# Altium schematic sheet 02_SRAD_Avionics.SchDoc(02_SRAD_Avionics)
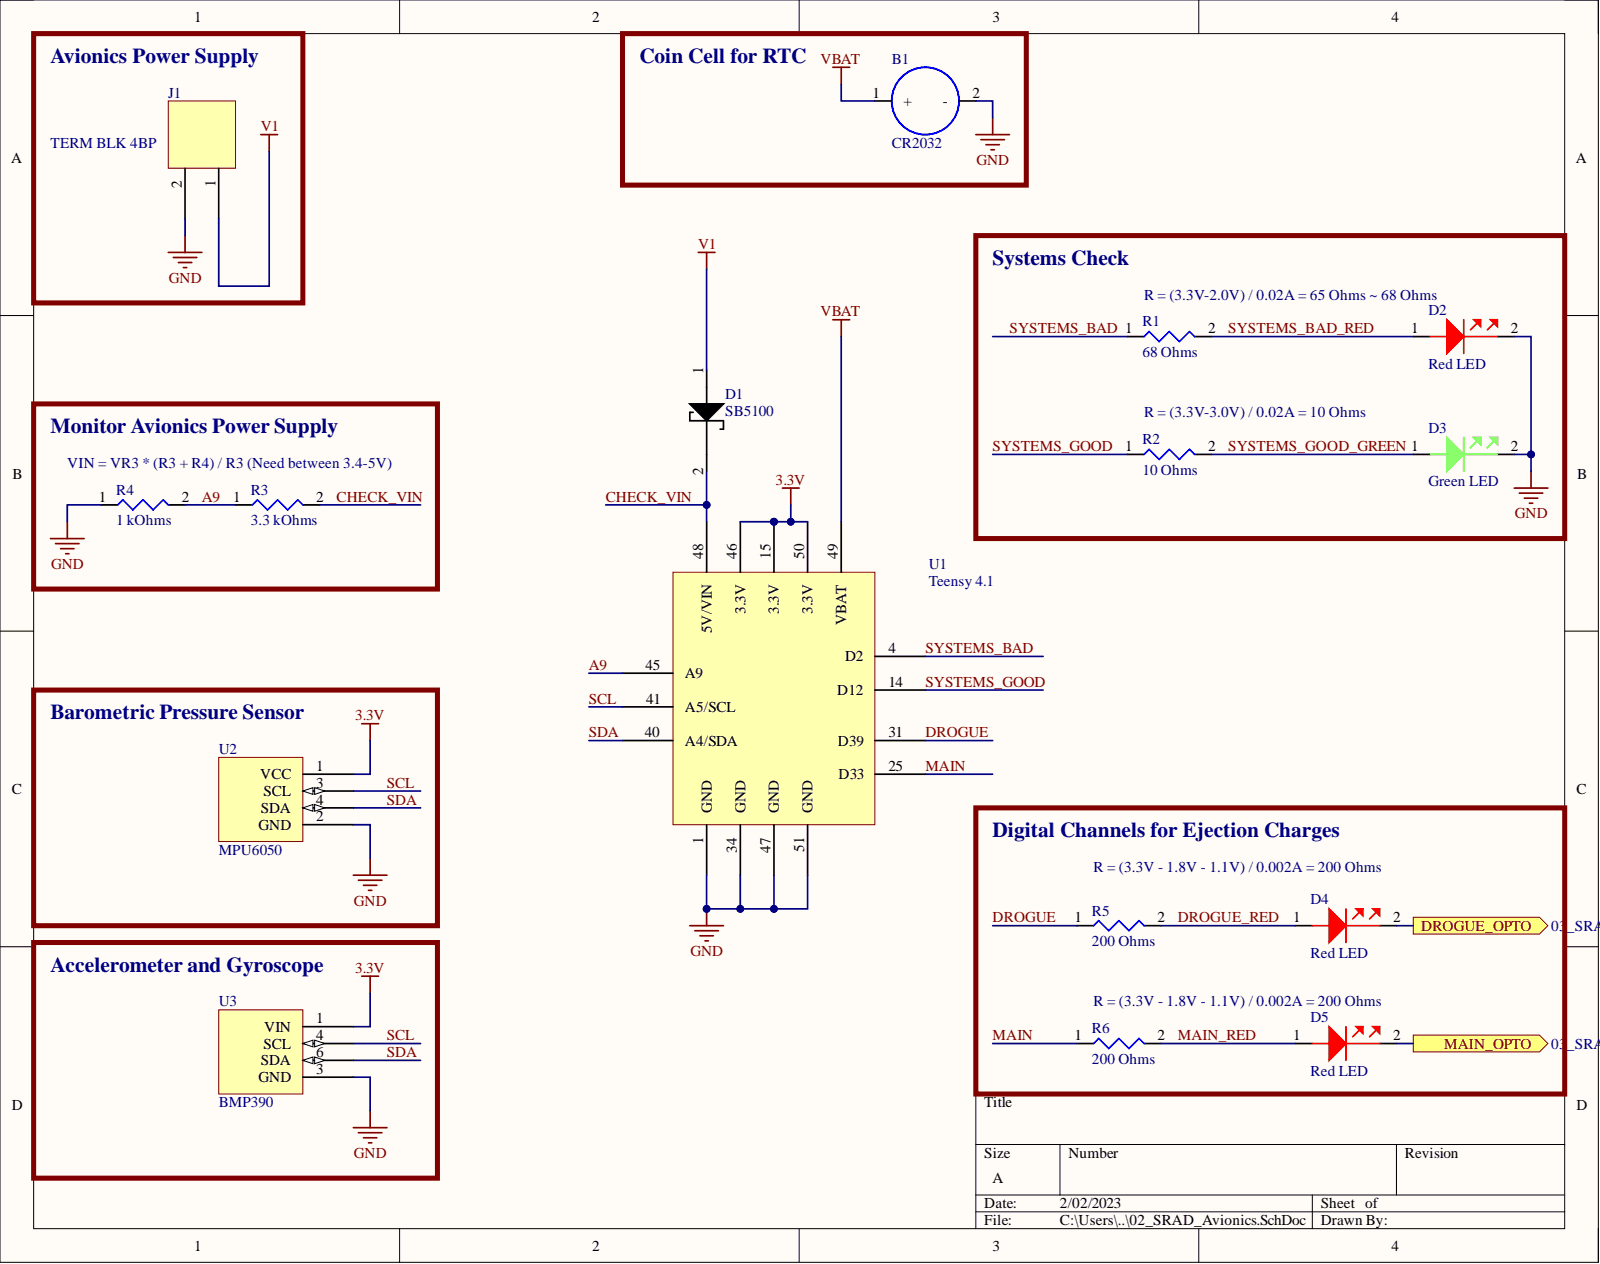
[diagram p0: 02_SRAD_Avionics.SchDoc]

## Parts
B1  CR2032  [CR2032]
D1  SB5100  [SB5100 Schottky Diode]
D2  Red LED  [Red LED THT]
D3  Green LED  Color: Green  [Green LED THT]
D4  Red LED  [Red LED THT]
D5  Red LED  [Red LED THT]
J1  TERM BLK 4BP  [PCB TERM BLK 2P 2.54MM]
R1  68 Ohms  [68 Ohm Yageo SMD]
R2  10 Ohms  [10 Ohm Yageo SMD]
R3  3.3 kOhms  [3.3 kOhm Yageo SMD]
R4  1 kOhms  [1 kOhm Yageo SMD]
R5  200 Ohms  [200 Ohm Yageo SMD]
R6  200 Ohms  [200 Ohm Yageo SMD]
U1  Teensy 4.1  [Teensy 4.1]
U2  MPU6050  [MPU6050]
U3  BMP390  [BMP390]

## Ports
DROGUE_OPTO, MAIN_OPTO

## Nets
3.3V: U1.15(3.3V) U1.46(3.3V) U1.50(3.3V) U2.1(VCC) U3.1(VIN)
GND: B1.2 D2.2 D3.2 J1.2 R4.1 U1.1(GND) U1.34(GND) U1.47(GND) U1.51(GND) U2.2(GND) U3.3(GND)
V1: D1.1 J1.1
VBAT: B1.1 U1.49(VBAT)
A9: R3.1 R4.2 U1.45(A9)
CHECK_VIN: D1.2 R3.2 U1.48(5V/VIN)
DROGUE: R5.1 U1.31(D39)
DROGUE_OPTO: D4.2 ; port DROGUE_OPTO
DROGUE_RED: D4.1 R5.2
MAIN: R6.1 U1.25(D33)
MAIN_OPTO: D5.2 ; port MAIN_OPTO
MAIN_RED: D5.1 R6.2
SCL: U1.41(A5/SCL) U2.3(SCL) U3.4(SCL)
SDA: U1.40(A4/SDA) U2.4(SDA) U3.6(SDA)
SYSTEMS_BAD: R1.1 U1.4(D2)
SYSTEMS_BAD_RED: D2.1 R1.2
SYSTEMS_GOOD: R2.1 U1.14(D12)
SYSTEMS_GOOD_GREEN: D3.1 R2.2

## Library data of the parts
B1 = CR2032: CR2032 Coin cell battery of 3V.; Component Kind: Standard; Library Name: SRAD_SAC_Schlib.SchLib
D1 = SB5100 Schottky Diode: Diode 100 V 5A Through Hole; Manufacturer: ON Semiconductor / Fairchild; Element Configuration: Single; Forward Voltage: 850mV; Max Forward Surge Current (Ifsm): 100A; Max Repetitive Reverse Voltage (Vrrm): 100V; Max Reverse Current: 500uA; Max Surge Current: 150A; Polarity: Standard; Average Rectified Current: 5A; Case/Package: DO-201AD; Category: Uncategorized; Component Kind: Standard; ComponentLink1Description: https://datasheet.ciiva.com/911/sb5100-911093.pdf?src-supplier=Avnet; ComponentLink1URL: https://datasheet.ciiva.com/911/sb5100-911093.pdf?src-supplier=Avnet; ComponentLink2Description: https://datasheet.ciiva.com/4714/sb5100-191746-4714390.pdf?src-supplier=Mouser; ComponentLink2URL: https://datasheet.ciiva.com/4714/sb5100-191746-4714390.pdf?src-supplier=Mouser; ComponentLink3Description: https://datasheet.ciiva.com/7965/78621-7965207.pdf?src-supplier=Element14; ComponentLink3URL: https://datasheet.ciiva.com/7965/78621-7965207.pdf?src-supplier=Element14; ComponentLink4Description: https://datasheet.ciiva.com/911/sb5100-911279.pdf?src-supplier=Digi-Key; ComponentLink4URL: https://datasheet.ciiva.com/911/sb5100-911279.pdf?src-supplier=Digi-Key; ComponentLink5Description: https://datasheet.ciiva.com/911/sb5100-911278.pdf?src-supplier=Digi-Key; ComponentLink5URL: https://datasheet.ciiva.com/911/sb5100-911278.pdf?src-supplier=Digi-Key; Current Rating: 5A; Diameter: 5.6mm; Forward Current: 5A; Height: 9.5mm; Lead Free: Lead Free; Length: 0.25inch; Manufacturer Part Number: SB5100; Max Operating Temperature: 150°C; Max Reverse Leakage Current: 500uA; Max Reverse Voltage (DC): 100V; Min Operating Temperature: -50°C; Mounting Technology: Through Hole; Output Current: 5A; Package Quantity: 1; Packaging: Tape and Reel; Peak Non-Repetitive Surge Current: 150A; Pins: 2; Pricing 1: 1=1.21000 (USD); Radiation Hardening: No; Reach SVHC Compliant: Yes; RoHS: Yes; RoHS Compliant: Yes; Series: -; Stock 1: 1; Supplier 1: Digi-Key; Supplier Part Number 1: SB5100FSCT-ND; Voltage Rating (DC): 100V; Weight: 0.36g; Width: 0.25inch; Library Name: SRAD_SAC_Schlib.SchLib
D2 D4 D5 = Red LED THT: Red 627nm LED Lighting Color HL3P Radial HL3P-BR60-J00DD; Manufacturer: Broadcom; Category: Uncategorized; Component Kind: Standard; Manufacturer Part Number: HL3P-BR60-J00DD; Pricing 1: 1=0.59000, 10=0.38500, 100=0.25470, 1000=0.19663 (USD); RoHS: No; Stock 1: 4693; Supplier 1: Digi-Key; Supplier Part Number 1: 516-HL3P-BR60-J00DDCT-ND; Library Name: SRAD_SAC_Schlib.SchLib
D3 = Green LED THT: Green 525nm LED Indication - Discrete 3.2V Radial HLMP-LM65-Z30DD; Manufacturer: Broadcom Avago; Forward Current: 20mA; Lens Style: Elliptical,Diffused,Colored; Lens Transparency: Diffused; Luminous Intensity: 3720mcd; Number of Elements: 1; Power Dissipation: 114mW; Reverse Voltage: 5V; Viewing Angle: 50°; Wavelength: 540nm; Case/Package: Radial; Category: LEDs; Component Kind: Standard; ComponentLink1Description: https://datasheet.ciiva.com/5786/303222-5786291.pdf?src-supplier=Farnell; ComponentLink1URL: https://datasheet.ciiva.com/5786/303222-5786291.pdf?src-supplier=Farnell; Depth: 3mm; Dominant Wavelength: 525nm; Forward Voltage: 3.2V; Height: 10.5mm; Length: 3.8mm; Lens Color: Green; Manufacturer Part Number: HLMP-LM65-Z30DD; Max Operating Temperature: 85°C; Min Operating Temperature: -40°C; Mounting Technology: Through Hole; Number of LEDs: 1; Package Quantity: 2000; Packaging: Tape and Reel; Pins: 2; Radiation Hardening: No; Reach SVHC Compliant: Yes; RoHS: Yes; RoHS Compliant: Yes; Series: -; Supplier 1: Digi-Key; Supplier Part Number 1: HLMP-LM65-Z30DD-ND; Test Current: 20mA; Library Name: SRAD_SAC_Schlib.SchLib
J1 = PCB TERM BLK 2P 2.54MM: 2 Position Wire to Board Terminal Block Horizontal with Board 0.100" (2.54mm) Through Hole 10A 150V 16-30 AWG 0.2 Nm M1.6 screw -40°C ~ 105°C; Manufacturer: TE Connectivity; Manufacturer Part Number: 282834-2; Approvals: UL; Closed End: No (Without); Connector Type: Receptacle; Gender: Male; Number of Circuits: 2; Number of Levels: 1; Plating: Brass,Steel; Screwless Terminal Block: Yes (With); Tail Length: 3.4mm; Wire Gauge (Max): 30AWG; Category: Terminal Blocks; Color: Green; Component Kind: Standard; ComponentLink1Description: https://datasheet.ciiva.com/16386/282834-2-16386363.pdf?src-supplier=Future+Electronics; ComponentLink1URL: https://datasheet.ciiva.com/16386/282834-2-16386363.pdf?src-supplier=Future+Electronics; ComponentLink2Description: https://datasheet.ciiva.com/30166/eng-cs-1308389-eurostyle-terminal-blocks-0607-30166974.pdf?src-supplier=Onlinecomponents.com; ComponentLink2URL: https://datasheet.ciiva.com/30166/eng-cs-1308389-eurostyle-terminal-blocks-0607-30166974.pdf?src-supplier=Onlinecomponents.com; ComponentLink3Description: https://datasheet.ciiva.com/14609/70086732-14609670.pdf?src-supplier=Allied+Electronics; ComponentLink3URL: https://datasheet.ciiva.com/14609/70086732-14609670.pdf?src-supplier=Allied+Electronics; ComponentLink4Description: https://datasheet.ciiva.com/15169/getdatasheetpartid-155864-15169558.pdf?src-supplier=Verical; ComponentLink4URL: https://datasheet.ciiva.com/15169/getdatasheetpartid-155864-15169558.pdf?src-supplier=Verical; ComponentLink5Description: https://datasheet.ciiva.com/3141/eng-cs-1308389-eurostyle-terminal-blocks-0607-3141115.pdf?src-supplier=Avnet; ComponentLink5URL: https://datasheet.ciiva.com/3141/eng-cs-1308389-eurostyle-terminal-blocks-0607-3141115.pdf?src-supplier=Avnet; Contact Material: Brass; Contact Plating: Tin; Current: 10A; Current Rating: 10A; Depth: 6.5mm; ELV: Compliant; Flammability Rating: UL94 V-0; Housing Color: Green; Housing Material: Polyamide; Interlock: No (Without); Lead Free: Lead Free; Length: 5.54mm; Material: Nylon; Max Current Rating: 10A; Max Operating Temperature: 105°C; Max Supply Voltage (AC): 150V; Max Voltage Rating (AC): 150V; Min Operating Temperature: -40°C; Mounting Technology: Through Hole; Number of Contacts: 2; Number of Poles: 2; Number of Positions: 2; Number of Positions Per Level: 2; Number of Rows: 1; Orientation: Straight; Package Quantity: 300; Packaging: Bulk; Pitch: 2.54mm; Pricing 1: 1=1.95000, 10=1.47400, 25=1.36640, 50=1.22860, 100=1.19860, 250=1.04876, 500=0.95886, 1000=0.86896, 5000=0.76409 (USD); Radiation Hardening: No; RoHS: Yes; RoHS Compliant: Yes; Screw Flange: No (Without); Series: Buchanan; Side Stackable: Yes (With); Stock 1: 34508; Supplier 1: Digi-Key; Supplier Part Number 1: A98333-ND; Termination: Solder; Thread Size: M1.6; Voltage: 150V; Voltage Rating: 150V; Width: 6.5mm; Wire Gauge (Min): 30AWG; Wire/Cable Gauge: 30AWG; Library Name: SRAD_SAC_Schlib.SchLib
R1 = 68 Ohm Yageo SMD: 68 Ohms ±1% 1W Chip Resistor 2512 (6432 Metric) Moisture Resistant Thick Film; Manufacturer: Yageo; Manufacturer Part Number: RC2512FK-0768RL; Temperature Coefficient: 100ppm/°C; Value: 68R; Voltage Rating: 200V; Case Code (Imperial): 2512; Case Code (Metric): 6432; Case/Package: 2512; Category: Chip SMD Resistors; Component Kind: Standard; ComponentLink1Description: https://datasheet.ciiva.com/7672/638316-7672008.pdf?src-supplier=Element14; ComponentLink1URL: https://datasheet.ciiva.com/7672/638316-7672008.pdf?src-supplier=Element14; ComponentLink2Description: https://datasheet.ciiva.com/7672/638316-7672009.pdf?src-supplier=Element14; ComponentLink2URL: https://datasheet.ciiva.com/7672/638316-7672009.pdf?src-supplier=Element14; Construction: Rectangular; Contact Plating: Tin,Matte; Depth: 3.1mm; Features: Moisture Resistant; Height: 0.026inch; Length: 0.25inch; Max Operating Temperature: 155°C; Max Power Dissipation: 1W; Military Standard: Not; Min Operating Temperature: -55°C; Number of Terminations: 2; Packaging: Tape and Reel; Power Rating: 1W; Pricing 1: 4000=0.05361, 8000=0.04850, 12000=0.04629, 28000=0.04435, 100000=0.04255 (USD); Radiation Hardening: No; Resistance Tolerance: 1%; Resistor Type: Thick Film; RoHS: Yes; RoHS Compliant: Yes; Series: RC; Supplier 1: Digi-Key; Supplier Part Number 1: 13-RC2512FK-0768RLTR-ND; Termination: SMD/SMT; Tolerance: 1%; Width: 0.122inch; Library Name: SRAD_SAC_Schlib.SchLib
R2 = 10 Ohm Yageo SMD: 10 Ohms ±1% 1W Chip Resistor 2512 (6432 Metric) Moisture Resistant Thick Film; Manufacturer: Yageo; Manufacturer Part Number: RC2512FK-0710RL; Temperature Coefficient: 200ppm/°C; Value: 10R; Voltage Rating: 200V; Case Code (Imperial): 2512; Case Code (Metric): 6432; Case/Package: 2512; Category: Chip SMD Resistors; Component Kind: Standard; ComponentLink1Description: https://datasheet.ciiva.com/23789/442906-23789776.pdf?src-supplier=Newark; ComponentLink1URL: https://datasheet.ciiva.com/23789/442906-23789776.pdf?src-supplier=Newark; ComponentLink2Description: https://datasheet.ciiva.com/7671/638316-7671951.pdf?src-supplier=Element14; ComponentLink2URL: https://datasheet.ciiva.com/7671/638316-7671951.pdf?src-supplier=Element14; ComponentLink3Description: https://datasheet.ciiva.com/12667/pyu-rc2512-51-rohs-l-4-12667005.pdf?src-supplier=Mouser; ComponentLink3URL: https://datasheet.ciiva.com/12667/pyu-rc2512-51-rohs-l-4-12667005.pdf?src-supplier=Mouser; ComponentLink4Description: https://datasheet.ciiva.com/16741/pyu-rc2512-51-rohs-l-4-16741660.pdf?src-supplier=Future+Electronics; ComponentLink4URL: https://datasheet.ciiva.com/16741/pyu-rc2512-51-rohs-l-4-16741660.pdf?src-supplier=Future+Electronics; Construction: Rectangular; Depth: 3.1mm; Features: Moisture Resistant; Height: 0.026inch; Lead Free: Lead Free; Length: 0.25inch; Max Operating Temperature: 155°C; Max Power Dissipation: 1W; Military Standard: Not; Min Operating Temperature: -55°C; Number of Terminations: 2; Packaging: Tape and Reel; Power Rating: 1W; Pricing 1: 4000=0.10208, 8000=0.09187, 12000=0.08983, 28000=0.08677, 100000=0.08425 (USD); Resistance Tolerance: 1%; Resistor Type: Thick Film; RoHS: Yes; RoHS Compliant: Yes; Series: RC; Stock 1: 164000; Supplier 1: Digi-Key; Supplier Part Number 1: YAG3389TR-ND; Technology: THICK FILM; Termination: SMD/SMT; Tolerance: 1%; Width: 0.122inch; Working Voltage: 200V; Library Name: SRAD_SAC_Schlib.SchLib
R3 = 3.3 kOhm Yageo SMD: 3.3 kOhms ±1% 1W Chip Resistor 2512 (6432 Metric) Moisture Resistant Thick Film; Manufacturer: Yageo; Manufacturer Part Number: RC2512FK-073K3L; Temperature Coefficient: 100ppm/°C; Value: 3.3kR; Voltage Rating: 200V; Case Code (Imperial): 2512; Case Code (Metric): 6432; Case/Package: 2512; Category: Chip SMD Resistors; Component Kind: Standard; ComponentLink1Description: https://datasheet.ciiva.com/7671/638316-7671990.pdf?src-supplier=Element14; ComponentLink1URL: https://datasheet.ciiva.com/7671/638316-7671990.pdf?src-supplier=Element14; ComponentLink2Description: https://datasheet.ciiva.com/7671/638316-7671991.pdf?src-supplier=Element14; ComponentLink2URL: https://datasheet.ciiva.com/7671/638316-7671991.pdf?src-supplier=Element14; ComponentLink3Description: https://datasheet.ciiva.com/12575/pyu-rc2512-51-rohs-l-4-12575433.pdf?src-supplier=Mouser; ComponentLink3URL: https://datasheet.ciiva.com/12575/pyu-rc2512-51-rohs-l-4-12575433.pdf?src-supplier=Mouser; Construction: Rectangular; Contact Plating: Tin,Lead; Depth: 3.1mm; Features: Moisture Resistant; Height: 0.026inch; Length: 0.25inch; Max Operating Temperature: 155°C; Max Power Dissipation: 1W; Military Standard: Not; Min Operating Temperature: -55°C; Number of Terminations: 2; Packaging: Tape and Reel; Power Rating: 1W; Pricing 1: 1=0.41000, 10=0.29400, 100=0.11740, 1000=0.05786 (USD); Radiation Hardening: No; Resistance Tolerance: 1%; Resistor Type: Thick Film; RoHS: Yes; RoHS Compliant: Yes; Series: RC; Stock 1: 6193; Supplier 1: Digi-Key; Supplier Part Number 1: 13-RC2512FK-073K3LCT-ND; Termination: SMD/SMT; Tolerance: 1%; Width: 3.1mm; Library Name: SRAD_SAC_Schlib.SchLib
R4 = 1 kOhm Yageo SMD: 1 kOhms ±1% 1W Chip Resistor 2512 (6432 Metric) Moisture Resistant Thick Film; Manufacturer: Yageo; Manufacturer Part Number: RC2512FK-071KL; Temperature Coefficient: 100ppm/°C; Value: 1kR; Voltage Rating: 200V; Case Code (Imperial): 2512; Case Code (Metric): 6432; Case/Package: 2512; Category: Chip SMD Resistors; Component Kind: Standard; ComponentLink1Description: https://datasheet.ciiva.com/7671/638316-7671963.pdf?src-supplier=Element14; ComponentLink1URL: https://datasheet.ciiva.com/7671/638316-7671963.pdf?src-supplier=Element14; ComponentLink2Description: https://datasheet.ciiva.com/7671/638316-7671962.pdf?src-supplier=Element14; ComponentLink2URL: https://datasheet.ciiva.com/7671/638316-7671962.pdf?src-supplier=Element14; ComponentLink3Description: https://datasheet.ciiva.com/12555/pyu-rc2512-51-rohs-l-4-12555290.pdf?src-supplier=Mouser; ComponentLink3URL: https://datasheet.ciiva.com/12555/pyu-rc2512-51-rohs-l-4-12555290.pdf?src-supplier=Mouser; ComponentLink4Description: https://datasheet.ciiva.com/8896/pyu-rc2512-51-rohs-l-4-8896186.pdf?src-supplier=Avnet; ComponentLink4URL: https://datasheet.ciiva.com/8896/pyu-rc2512-51-rohs-l-4-8896186.pdf?src-supplier=Avnet; ComponentLink5Description: https://datasheet.ciiva.com/17421/pyu-rc2512-51-rohs-l-4-17421912.pdf?src-supplier=Future+Electronics; ComponentLink5URL: https://datasheet.ciiva.com/17421/pyu-rc2512-51-rohs-l-4-17421912.pdf?src-supplier=Future+Electronics; Construction: Rectangular; Contact Plating: Tin,Matte; Depth: 3.1mm; Features: Moisture Resistant; Height: 0.026inch; Lead Free: Lead Free; Length: 0.25inch; Max Operating Temperature: 155°C; Max Power Dissipation: 1W; Military Standard: Not; Min Operating Temperature: -55°C; Number of Terminations: 2; Packaging: Tape and Reel; Power Rating: 1W; Pricing 1: 4000=0.05361, 8000=0.04850, 12000=0.04629, 28000=0.04435, 100000=0.04255 (USD); Radiation Hardening: No; Resistance Tolerance: 1%; Resistor Type: Thick Film; RoHS: Yes; RoHS Compliant: Yes; Series: RC; Stock 1: 4000; Supplier 1: Digi-Key; Supplier Part Number 1: YAG3390TR-ND; Termination: SMD/SMT; Tolerance: 1%; Width: 3.1mm; Library Name: SRAD_SAC_Schlib.SchLib
R5 R6 = 200 Ohm Yageo SMD: 200 Ohms ±1% 1W Chip Resistor 2512 (6432 Metric) Moisture Resistant Thick Film made by Yageo; Manufacturer Part Number: RC2512FK-07200RL; Temperature Coefficient: 100ppm/°C; Value: 200R; Voltage Rating: 200V; Case Code (Imperial): 2512; Case Code (Metric): 6432; Case/Package: 2512; Category: Chip SMD Resistors; Component Kind: Standard; ComponentLink1Description: https://datasheet.ciiva.com/12555/pyu-rc2512-51-rohs-l-4-12555336.pdf?src-supplier=Mouser; ComponentLink1URL: https://datasheet.ciiva.com/12555/pyu-rc2512-51-rohs-l-4-12555336.pdf?src-supplier=Mouser; Construction: Rectangular; Contact Plating: Tin,Matte; Depth: 3.1mm; Features: Moisture Resistant; Height: 0.026inch; Length: 6.35mm; Manufacturer: Yageo; Max Operating Temperature: 155°C; Max Power Dissipation: 1W; Military Standard: Not; Min Operating Temperature: -55°C; Number of Terminations: 2; Packaging: Tape and Reel; Power Rating: 1W; Pricing 1: 1=0.41000, 10=0.29400, 100=0.11740, 1000=0.05786 (USD); Radiation Hardening: No; Resistor Type: Thick Film; RoHS: Yes; RoHS Compliant: Yes; Series: RC; Stock 1: 3518; Supplier 1: Digi-Key; Supplier Part Number 1: 13-RC2512FK-07200RLCT-ND; Termination: SMD/SMT; Tolerance: 1%; Width: 0.122inch; Library Name: SRAD_SAC_Schlib.SchLib
U1 = Teensy 4.1: RT1062 Teensy 4.1 - ARM® Cortex®-M7 MCU 32-Bit Embedded Evaluation Board 600 MHz 100 mA RTC Built-in microSD Card reader Arduino IDE compatible; Manufacturer: SparkFun; Manufacturer Part Number: DEV-16996; Category: Embedded Processors and Controllers; Component Kind: Standard; Pricing 1: 1=31.88000 (USD); RoHS: No; Stock 1: 300; Supplier 1: Digi-Key; Supplier Part Number 1: 1568-DEV-16996-ND; Library Name: SRAD_SAC_Schlib.SchLib
U2 = MPU6050: Accelerometer and gyroscope 6 DOF sensor. GY-521 breakout board by HiLetgo and MPU6050 chip by Invensense.; Component Kind: Standard; Library Name: SRAD_SAC_Schlib.SchLib
U3 = BMP390: Barometric pressure sensor manufactured by Bosch.; Component Kind: Standard; Library Name: SRAD_SAC_Schlib.SchLib
